# Altium schematic sheet RTC & Buzzer.SchDoc
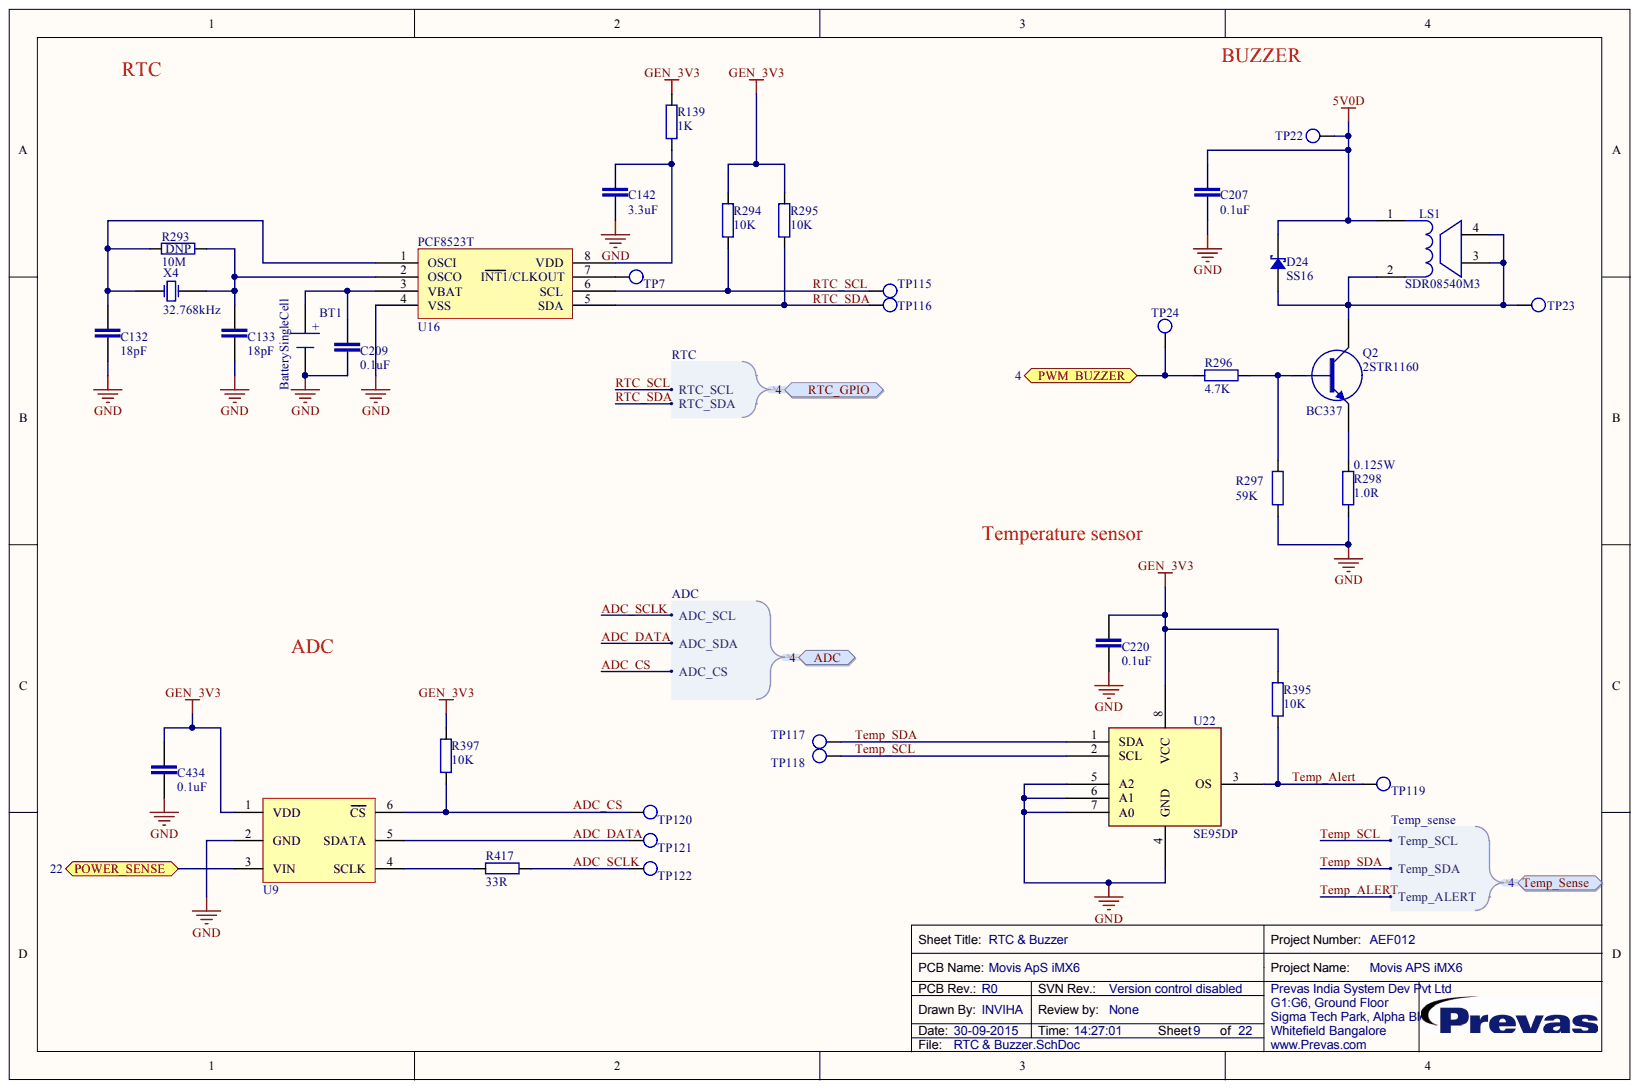
[diagram p0: RTC & Buzzer.SchDoc]

## Parts
BT1  BatterySingleCell  [BAT_BU2032SM-BT-GTR]
C132  18pF  [CAPC1005X55N]
C133  18pF  [CAPC1005X55N]
C142  3.3uF  [CAPC1608X90N]
C207  0.1uF  [CAPC1005X55N]
C209  0.1uF  [CAPC1005X55N]
C220  0.1uF  [CAPC1005X55N]
C434  0.1uF  [CAPC1005X55N]
D24  SS16  [DIOM5226X262N]
LS1  SDR08540M3  [BUZZER_SDR08540M3]
Q2  2STR1160  [SOT95P240X110-3N]
R139  1K  [RESC1608X55N]
R293  10M  Fitted: DNP  [RESC1608X55N]
R294  10K  [RESC1608X55N]
R295  10K  [RESC1608X55N]
R296  4.7K  [RESC1608X55N]
R297  59K  [RESC1608X55N]
R298  1.0R  elec_power_rating: 0.125W  [RESC2012X60N]
R395  10K  [RESC1608X55N]
R397  10K  [RESC1608X55N]
R417  33R  [RESC1608X55N]
TP7  Testpoint  [TP_SMD]  (value not drawn)  (no BOM)
TP22  Testpoint  [TP_SMD]  (value not drawn)  (no BOM)
TP23  Testpoint  [TP_SMD]  (value not drawn)  (no BOM)
TP24  Testpoint  [TP_SMD]  (value not drawn)  (no BOM)
TP115  Testpoint  [TP_SMD]  (value not drawn)  (no BOM)
TP116  Testpoint  [TP_SMD]  (value not drawn)  (no BOM)
TP117  Testpoint  [TP_SMD]  (value not drawn)  (no BOM)
TP118  Testpoint  [TP_SMD]  (value not drawn)  (no BOM)
TP119  Testpoint  [TP_SMD]  (value not drawn)  (no BOM)
TP120  Testpoint  [TP_SMD]  (value not drawn)  (no BOM)
TP121  Testpoint  [TP_SMD]  (value not drawn)  (no BOM)
TP122  Testpoint  [TP_SMD]  (value not drawn)  (no BOM)
U9  ADCS7476_SCH  [SOT95P280X145-6N]  (value not drawn)
U16  PCF8523T  [SOIC127P600X175-8N]
U22  SE95DP  [TSOP65P490X110-8N]
X4  32.768kHz  [OSC_AB26TRB-32.768kHz]

## Ports
ADC, ADC.ADC_CS, ADC.ADC_SCL, ADC.ADC_SDA, POWER_SENSE, PWM_BUZZER, RTC_GPIO, RTC_GPIO.RTC_SCL, RTC_GPIO.RTC_SDA, TEMP_SENSE, TEMP_SENSE.TEMP_ALERT, TEMP_SENSE.TEMP_SCL, TEMP_SENSE.TEMP_SDA

## Nets
5V0D: C207.2 D24.C LS1.1 TP22.1
GEN_3V3: C220.2 C434.2 R139.2 R294.2 R295.2 R395.1 R397.1 U9.1(VDD) U22.8(VCC)
GND: BT1.2 C132.1 C133.1 C142.1 C207.1 C209.1 C220.1 C434.1 R297.1 R298.1 U9.2(GND) U16.4(VSS) U22.4(GND) U22.5(A2) U22.6(A1) U22.7(A0)
ADC_CS: R397.2 TP120.1 U9.6(CS) ; port ADC
ADC_DATA: TP121.1 U9.5(SDATA) ; port ADC
ADC_SCLK: R417.2 TP122.1 ; port ADC
POWER_SENSE: U9.3(VIN) ; port POWER_SENSE
PWM_BUZZER: R296.2 TP24.1 ; port PWM_BUZZER
RTC_SCL: R294.1 TP115.1 U16.6(SCL) ; port RTC_GPIO
RTC_SDA: R295.1 TP116.1 U16.5(SDA) ; port RTC_GPIO
TEMP_ALERT: R395.2 TP119.1 U22.3(OS) ; port TEMP_SENSE
TEMP_SCL: TP118.1 U22.2(SCL) ; port TEMP_SENSE
TEMP_SDA: TP117.1 U22.1(SDA) ; port TEMP_SENSE
NetBT1_1: BT1.1 C209.2 U16.3(VBAT)
NetC132_2: C132.2 R293.1 U16.1(OSCI) X4.2
NetC133_2: C133.2 R293.2 U16.2(OSCO) X4.1
NetC142_2: C142.2 R139.1 U16.8(VDD)
NetD24_A: D24.A LS1.2 LS1.3 LS1.4 Q2.3 TP23.1
NetQ2_1: Q2.1 R296.1 R297.2
NetQ2_2: Q2.2 R298.2
NetR417_1: R417.1 U9.4(SCLK)
NetTP7_1: TP7.1 U16.7(INT1/CLKOUT)

## Library data of the parts
BT1 = GEPW-247: BATTERY HOLDER, 20MM COIN CELL, SURFACE MOUNT (SMT); ref_part_type: /elmech/battery/; source_manufacturer_1: Memory Protection Devices; source_manufacturer_1_pn: BU2032SM-BT-GTR; cae_altium_pcb_status: Prototype; Database Table Name: altium_default; db_rev: 6039; elec_value: 20mm COIN CELL holder; phy_height: 4.8mm; phy_material: Contacts Gold flash plating; phy_mounting: SMD; ref_datasheet: GEPW-242; ref_keyword: BATTERY HOLDER, 20MM COIN CELL 2032; cae_altium_sch_status: Prototype; Component Kind: Standard; ComponentLink1Description: Datasheet; ComponentLink1URL: https://cop201.prevas.dk/viewvc/datasheet/GEPW-242.pdf?root=hw_components&view=co; db_created: 2013-11-26 11:18:05.0; db_last_updated: 26-11-2013 11:18:05 AM; db_local_id: GEPW-247; db_status: Prototype; Library Name: altium_mysql.dblib
C132 C133 = INNISI-75: CAP CER 18PF 50V 5% NPO 0402; ref_part_type: /capacitor/non_polarized/ceramic/; source_manufacturer_1: Yageo; source_manufacturer_1_pn: CC0402JRNPO9BN180; cae_altium_pcb_status: Prototype; Database Table Name: altium_default; db_rev: 7571; elec_temp_range: -55 to +125C; phy_height: 0.55mm; phy_material: C0G; phy_mounting: SMD; phy_packaging_type: 0402; ref_datasheet: INNISI-71; ref_keyword: Ceramic Capacitor 0402; cae_altium_sch_status: Prototype; Component Kind: Standard; ComponentLink1Description: Datasheet; ComponentLink1URL: https://cop201.prevas.dk/viewvc/datasheet/INNISI-71.pdf?root=hw_components&view=co; db_created: 2015-08-03 03:51:37.0; db_last_updated: 03-08-2015 03:51:38; db_local_id: INNISI-75; db_status: Prototype; elec_tolerance: 5%; elec_value: 18pF; elec_voltage_rating: 50V; Library Name: altium_mysql.dblib
C142 = innisi-96: CAP CER 3.3UF 16V 10% X5R 0603; ref_part_type: /capacitor/non_polarized/ceramic/; source_manufacturer_1: TDK; source_manufacturer_1_pn: C1608X5R1C335K080AC; cae_altium_pcb_status: Prototype; Database Table Name: altium_default; db_rev: 7633; elec_temp_range: -55 to +85C; phy_height: 0.80mm; phy_mounting: SMD; phy_packaging_type: 0603; ref_datasheet: INNISI-85; ref_keyword: ceramic chip capacitor; cae_altium_sch_status: Prototype; Component Kind: Standard; ComponentLink1Description: Datasheet; ComponentLink1URL: https://cop201.prevas.dk/viewvc/datasheet/INNISI-85.pdf?root=hw_components&view=co; db_created: 2015-08-03 09:25:45.0; db_last_updated: 03-08-2015 09:25:47; db_local_id: INNISI-96; db_status: Prototype; elec_tolerance: 10%; elec_value: 3.3uF; elec_voltage_rating: 16V; phy_material: X5R; Library Name: altium_mysql.dblib
C207 C209 C220 C434 = INNISI-73: CAP CER 0.1UF 50V 10% X5R 0402; ref_part_type: /capacitor/non_polarized/ceramic/; source_manufacturer_1: TDK Corporation; source_manufacturer_1_pn: C1005X5R1H104K050BB; cae_altium_pcb_status: Prototype; Database Table Name: altium_default; db_rev: 7569; elec_temp_range: -55 to +85 C; phy_height: 0.55mm; phy_mounting: SMD; phy_packaging_type: 0402; ref_datasheet: INNISI-71; cae_altium_sch_status: Prototype; Component Kind: Standard; ComponentLink1Description: Datasheet; ComponentLink1URL: https://cop201.prevas.dk/viewvc/datasheet/INNISI-71.pdf?root=hw_components&view=co; db_created: 2015-08-03 03:34:59.0; db_last_updated: 03-08-2015 03:35:01; db_local_id: INNISI-73; db_status: Prototype; elec_tolerance: ±10%; elec_value: 0.1uF; elec_voltage_rating: 50V; phy_material: X5R; ref_keyword: CAP CER 0.1UF 50V 10% X5R 0402; Library Name: altium_mysql.dblib
D24 = TELA-23: Diode, Barrier Rectifier DO-214AC; ref_part_type: /diode/schottky/; source_manufacturer_1: Multicomp; cae_altium_pcb_status: Prototype; Database Table Name: altium_default; db_rev: 2272; elec_current_rating: 1A; elec_temp_range: -65 to +150; elec_voltage_rating: 60V; phy_height: 2.62mm; phy_mounting: SMD; ref_datasheet: TELA-12; ref_keyword: Schottky Diode SMD; cae_altium_sch_status: Prototype; Component Kind: Standard; ComponentLink1Description: Datasheet; ComponentLink1URL: https://cop201.prevas.dk/viewvc/datasheet/TELA-12.pdf?root=hw_components&view=co; db_created: 2010-04-08 13:07:36; db_last_updated: 6/3/2010 10:51:07 AM; db_local_id: TELA-23; db_status: Prototype; elec_value: SS16; phy_packaging_type: DO-214AC; source_manufacturer_1_pn: SS16; Library Name: altium_mysql.dblib
LS1 = (no symbol name): Electromagnetic Buzzer; ref_part_type: /elmech/transducer/piezo/; source_manufacturer_1: TDK; cae_altium_pcb_status: Prototype; db_local_id: INRAAN-61; db_rev: 6212; elec_current_rating: 85mA; elec_frequency_rating: 2670Hz; elec_temp_range: -40 TO 85; elec_voltage_rating: 5V; phy_height: 4.2; phy_mounting: SMD; ref_datasheet: INRAAN-63; cae_altium_sch_status: Prototype; Component Kind: Standard; ComponentLink1Description: Datasheet; ComponentLink1URL: https://cop201.prevas.dk/viewvc/datasheet/INRAAN-63.pdf?root=hw_components&view=co; db_created: 2013-12-11 10:06:55.0; db_last_updated: 12/11/2013 10:06:58 AM; db_status: Prototype; elec_value: SDR08540M3; ref_keyword: Buzzer; source_manufacturer_1_pn: SDR08540M3; Library Name: Movis-main-card.SCHLIB
Q2 = CHPE-41: Low voltage, fast switching NPN power transistors; ref_part_type: /transistor/bipolar/npn/; source_manufacturer_1: ST; cae_altium_pcb_status: Prototype; Database Table Name: altium_default; db_rev: 2450; elec_current_rating: 1A; elec_power_rating: 500mW; elec_temp_range: -65 to 150; elec_voltage_rating: 60V; phy_height: 1.1mm; phy_mounting: SMD; phy_packaging_type: SOT23-3; ref_datasheet: JEPE-47; cae_altium_sch_status: Prototype; Component Kind: Standard; ComponentLink1Description: Datasheet; ComponentLink1URL: https://cop201.prevas.dk/viewvc/datasheet/JEPE-47.pdf?root=hw_components&view=co; db_created: 2010-09-16 00:19:28.0; db_last_updated: 9/16/2010 12:19:28 AM; db_local_id: CHPE-41; db_status: Prototype; elec_value: 2STR1160; ref_keyword: NPN; source_manufacturer_1_pn: 2STR1160; Library Name: altium_mysql.dblib
R139 = INVIHA-11: RES SMD 1K OHM 1% 1/10W 0603; ref_part_type: /resistor/fixed/; source_manufacturer_1: Yageo; source_manufacturer_1_pn: RC0603FR-071KL; cae_altium_pcb_status: Prototype; Database Table Name: altium_default; db_rev: 7590; elec_power_rating: 0.1W; elec_temp_range: -55 to +155° C; phy_height: 0.55mm; phy_packaging_type: 0603; ref_datasheet: INVIHA-2; ref_keyword: Chip Resistor; cae_altium_sch_status: Prototype; Component Kind: Standard; ComponentLink1Description: Datasheet; ComponentLink1URL: https://cop201.prevas.dk/viewvc/datasheet/INVIHA-2.pdf?root=hw_components&view=co; db_created: 2015-08-03 06:39:44.0; db_last_updated: 03-08-2015 6:39:46 AM; db_local_id: INVIHA-11; db_status: Prototype; elec_tolerance: 1%; elec_value: 1K; phy_mounting: SMD; Library Name: altium_mysql.dblib
R293 = INVIHA-48: RES SMD 10M OHM 5% 1/10W 0603; ref_part_type: /resistor/fixed/; source_manufacturer_1: Yageo; source_manufacturer_1_pn: RC0603JR-0710ML; cae_altium_pcb_status: Prototype; Database Table Name: altium_default; db_rev: 7653; elec_power_rating: 0.1W; elec_temp_range: -55 to +155° C; phy_height: 0.55mm; phy_packaging_type: 0603; ref_datasheet: INVIHA-2; ref_keyword: Chip Resistor; cae_altium_sch_status: Prototype; Component Kind: Standard; ComponentLink1Description: Datasheet; ComponentLink1URL: https://cop201.prevas.dk/viewvc/datasheet/INVIHA-2.pdf?root=hw_components&view=co; db_created: 2015-08-04 04:48:09.0; db_last_updated: 04-08-2015 4:48:11 AM; db_local_id: INVIHA-48; db_status: Prototype; elec_tolerance: 5%; elec_value: 10M; phy_mounting: SMD; Library Name: altium_mysql.dblib
R294 R295 R395 R397 = INVIHA-3: RES SMD 10K OHM 1% 1/10W 0603; ref_part_type: /resistor/fixed/; source_manufacturer_1: Yageo; source_manufacturer_1_pn: RC0603FR-0710KL; cae_altium_pcb_status: Prototype; Database Table Name: altium_default; db_rev: 7575; elec_power_rating: 0.1W; elec_temp_range: -55 to +155° C; phy_height: 0.55mm; phy_packaging_type: 0603; ref_datasheet: INVIHA-2; ref_keyword: Chip Resistor; cae_altium_sch_status: Prototype; Component Kind: Standard; ComponentLink1Description: Datasheet; ComponentLink1URL: https://cop201.prevas.dk/viewvc/datasheet/INVIHA-2.pdf?root=hw_components&view=co; db_created: 2015-08-03 05:39:03.0; db_last_updated: 03-08-2015 5:39:05 AM; db_local_id: INVIHA-3; db_status: Prototype; elec_tolerance: 1%; elec_value: 10K; phy_mounting: SMD; Library Name: altium_mysql.dblib
R296 = INVIHA-5: RES SMD 4.7K OHM 1% 1/10W 0603; ref_part_type: /resistor/fixed/; source_manufacturer_1: Yageo; source_manufacturer_1_pn: RC0603FR-074K7L; cae_altium_pcb_status: Prototype; Database Table Name: altium_default; db_rev: 7581; elec_power_rating: 0.1W; elec_temp_range: -55 to +155° C; phy_height: 0.55mm; phy_packaging_type: 0603; ref_datasheet: INVIHA-4; ref_keyword: Chip Resistor; cae_altium_sch_status: Prototype; Component Kind: Standard; ComponentLink1Description: Datasheet; ComponentLink1URL: https://cop201.prevas.dk/viewvc/datasheet/INVIHA-4.pdf?root=hw_components&view=co; db_created: 2015-08-03 06:09:48.0; db_last_updated: 03-08-2015 6:09:49 AM; db_local_id: INVIHA-5; db_status: Prototype; elec_tolerance: 1%; elec_value: 4.7K; phy_mounting: SMD; Library Name: altium_mysql.dblib
R297 = INVIHA-29: RES SMD 59K OHM 1% 1/10W 0603; ref_part_type: /resistor/fixed/; source_manufacturer_1: Yageo; source_manufacturer_1_pn: RC0603FR-0759KL; cae_altium_pcb_status: Prototype; Database Table Name: altium_default; db_rev: 7620; elec_power_rating: 0.1W; elec_temp_range: -55 to +155° C; phy_height: 0.55mm; phy_packaging_type: 0603; ref_keyword: Chip Resistor; cae_altium_sch_status: Prototype; Component Kind: Standard; ComponentLink1Description: Datasheet; ComponentLink1URL: https://cop201.prevas.dk/viewvc/datasheet/INVIHA-2.pdf?root=hw_components&view=co; db_created: 2015-08-03 08:40:01.0; db_last_updated: 03-08-2015 8:40:03 AM; db_local_id: INVIHA-29; db_status: Prototype; elec_tolerance: 1%; elec_value: 59K; phy_mounting: SMD; ref_datasheet: INVIHA-2; Library Name: altium_mysql.dblib
R298 = MITO-20: Resistor 0805 General Purpose; ref_part_type: /resistor/fixed/; source_manufacturer_1: Phycomp; source_manufacturer_1_pn: 2322734*1008L; cae_altium_pcb_status: Prototype; Database Table Name: altium_default; db_rev: 2100; elec_current_rating: 2A; elec_temp_range: -55 to +155°C; elec_tolerance: 1%; elec_voltage_rating: 150V; phy_height: 0.60mm; phy_material: Thick film; phy_mounting: SMD; phy_packaging_type: 0805; ref_datasheet: CHKJ-6; ref_keyword: Thick film chip resistor; cae_altium_sch_status: Prototype; Component Kind: Standard; ComponentLink1Description: Datasheet; ComponentLink1URL: https://cop201.prevas.dk/viewvc/datasheet/CHKJ-6.pdf?root=hw_components&view=co; db_created: 2008-10-10 09:39:46; db_last_updated: 4/26/2010 10:43:50 AM; db_local_id: MITO-20; db_status: Prototype; elec_value: 1.0R; Library Name: altium_mysql.dblib
R417 = INVIHA-13: RES SMD 33 OHM 1% 1/10W 0603; ref_part_type: /resistor/fixed/; source_manufacturer_1: Yageo; source_manufacturer_1_pn: RC0603FR-0733RL; cae_altium_pcb_status: Prototype; Database Table Name: altium_default; db_rev: 7593; elec_power_rating: 0.1W; elec_temp_range: -55 to +155° C; phy_height: 0.55mm; phy_packaging_type: 0603; ref_datasheet: INVIHA-2; ref_keyword: Chip Resistor; cae_altium_sch_status: Prototype; Component Kind: Standard; ComponentLink1Description: Datasheet; ComponentLink1URL: https://cop201.prevas.dk/viewvc/datasheet/INVIHA-2.pdf?root=hw_components&view=co; db_created: 2015-08-03 06:49:16.0; db_last_updated: 03-08-2015 6:49:17 AM; db_local_id: INVIHA-13; db_status: Prototype; elec_tolerance: 1%; elec_value: 33R; phy_mounting: SMD; Library Name: altium_mysql.dblib
TP7 TP22-TP24 TP115-TP122 = JEPE-114: Test Point SMD; ref_part_type: /pcb_item/; cae_altium_pcb_status: Prototype; Database Table Name: altium_default; db_rev: 3281; cae_altium_sch_status: Prototype; ComponentLink1Description: Datasheet; db_created: 2008-08-18 11:39:42; db_local_id: JEPE-114; db_status: Prototype; elec_value: Test Point; phy_mounting: SMD; ref_keyword: Test  Point; Library Name: altium_mysql.dblib
  TP7 TP22-TP24: db_last_updated: 3/23/2011 2:14:06 PM
  TP115-TP122: db_last_updated: 23-03-2011 14:14:06
U9 = INNISI-21: IC ADC 12BIT 1MSPS SOT-23-6; ref_part_type: /integrated/converter/ad/; source_manufacturer_1: Texas Instruments; cae_altium_pcb_status: Prototype; Database Table Name: altium_default; db_rev: 7347; elec_temp_range: -40°C ~ 125°C; elec_value: ADCS7476AIMF; elec_voltage_rating: 2.7 V ~ 5.25 V; phy_mounting: SMD; ref_keyword: 12Bit ADC SPI; cae_altium_sch_status: Prototype; Component Kind: Standard; ComponentLink1Description: Datasheet; ComponentLink1URL: https://cop201.prevas.dk/viewvc/datasheet/INNISI-21.pdf?root=hw_components&view=co; db_created: 2015-06-01 10:55:05.0; db_last_updated: 01-06-2015 10:55:07; db_local_id: INNISI-21; db_status: Prototype; phy_packaging_type: SOT-23-6; ref_datasheet: INNISI-21; source_manufacturer_1_pn: ADCS7476AIMF; Library Name: altium_mysql.dblib
U16 = INNISI-8: Real Time Clock REAL-TIME CLOCK (RTC) AND CALENDAR; ref_part_type: /integrated/digital/RTC/; source_manufacturer_1: NXP; source_manufacturer_1_pn: PCF8523T/1; cae_altium_pcb_status: Prototype; Database Table Name: altium_default; db_rev: 7325; elec_temp_range: -40 C to +85 C; elec_voltage_rating: 1.6 V to 5.5 V; phy_mounting: SMD; phy_packaging_type: SOIC-8; ref_keyword: RTC,I2C; cae_altium_sch_status: Prototype; Component Kind: Standard; ComponentLink1Description: Datasheet; ComponentLink1URL: https://cop201.prevas.dk/viewvc/datasheet/INNISI-8.pdf?root=hw_components&view=co; db_created: 2015-05-27 07:26:15.0; db_last_updated: 27-05-2015 07:26:31; db_local_id: INNISI-8; db_status: Prototype; elec_value: PCF8523T; ref_datasheet: INNISI-8; Library Name: altium_mysql.dblib
U22 = INNISI-6: Digital Temperature Sensor; ref_part_type: /elmech/transducer/integrated_sensor/; source_manufacturer_1: NXP Semiconductors; source_manufacturer_1_pn: SE95DP,118; cae_altium_pcb_status: Prototype; Database Table Name: altium_default; db_rev: 7303; elec_temp_range: -55°C to +125°C; elec_voltage_rating: 2.8V - 5.5V; phy_mounting: SMD; phy_packaging_type: 8-TSSOP; cae_altium_sch_status: Prototype; Component Kind: Standard; ComponentLink1Description: Datasheet; ComponentLink1URL: https://cop201.prevas.dk/viewvc/datasheet/INNISI-6.pdf?root=hw_components&view=co; db_created: 2015-05-18 11:45:48.0; db_last_updated: 18-05-2015 11:45:50; db_local_id: INNISI-6; db_status: Prototype; elec_value: SE95DP; ref_datasheet: INNISI-6; ref_keyword: Temperature Sensor; Library Name: altium_mysql.dblib
X4 = MITO-92: Tuning Fork Surface Mount Crystal Oscillator; ref_part_type: /oscillator/crystal/; source_manufacturer_1: Abracon Corporation; source_manufacturer_1_pn: AB26TRB-32.768kHz; cae_altium_pcb_status: Prototype; Database Table Name: altium_default; db_rev: 4720; elec_power_rating: 1uW (max); elec_temp_range: -40°C to +85°C; elec_tolerance: ±20ppm; phy_height: 2.0mm; phy_mounting: SMD; phy_packaging_type: Cylinder; ref_datasheet: MITO-66; cae_altium_sch_status: Prototype; Component Kind: Standard; ComponentLink1Description: Datasheet; ComponentLink1URL: https://cop201.prevas.dk/viewvc/datasheet/MITO-66.pdf?root=hw_components&view=co; db_created: 2009-10-06 14:31:38; db_last_updated: 21-06-2012 15:07:32; db_local_id: MITO-92; db_status: Prototype; elec_frequency_rating: 32.768kHz; elec_value: 32.768kHz; ref_keyword: Crystal Oscillator; Library Name: altium_mysql.dblib
